# Altium schematic sheet EIS_Inverting_Adapter.SchDoc(EIS_Inverting_Adapter)
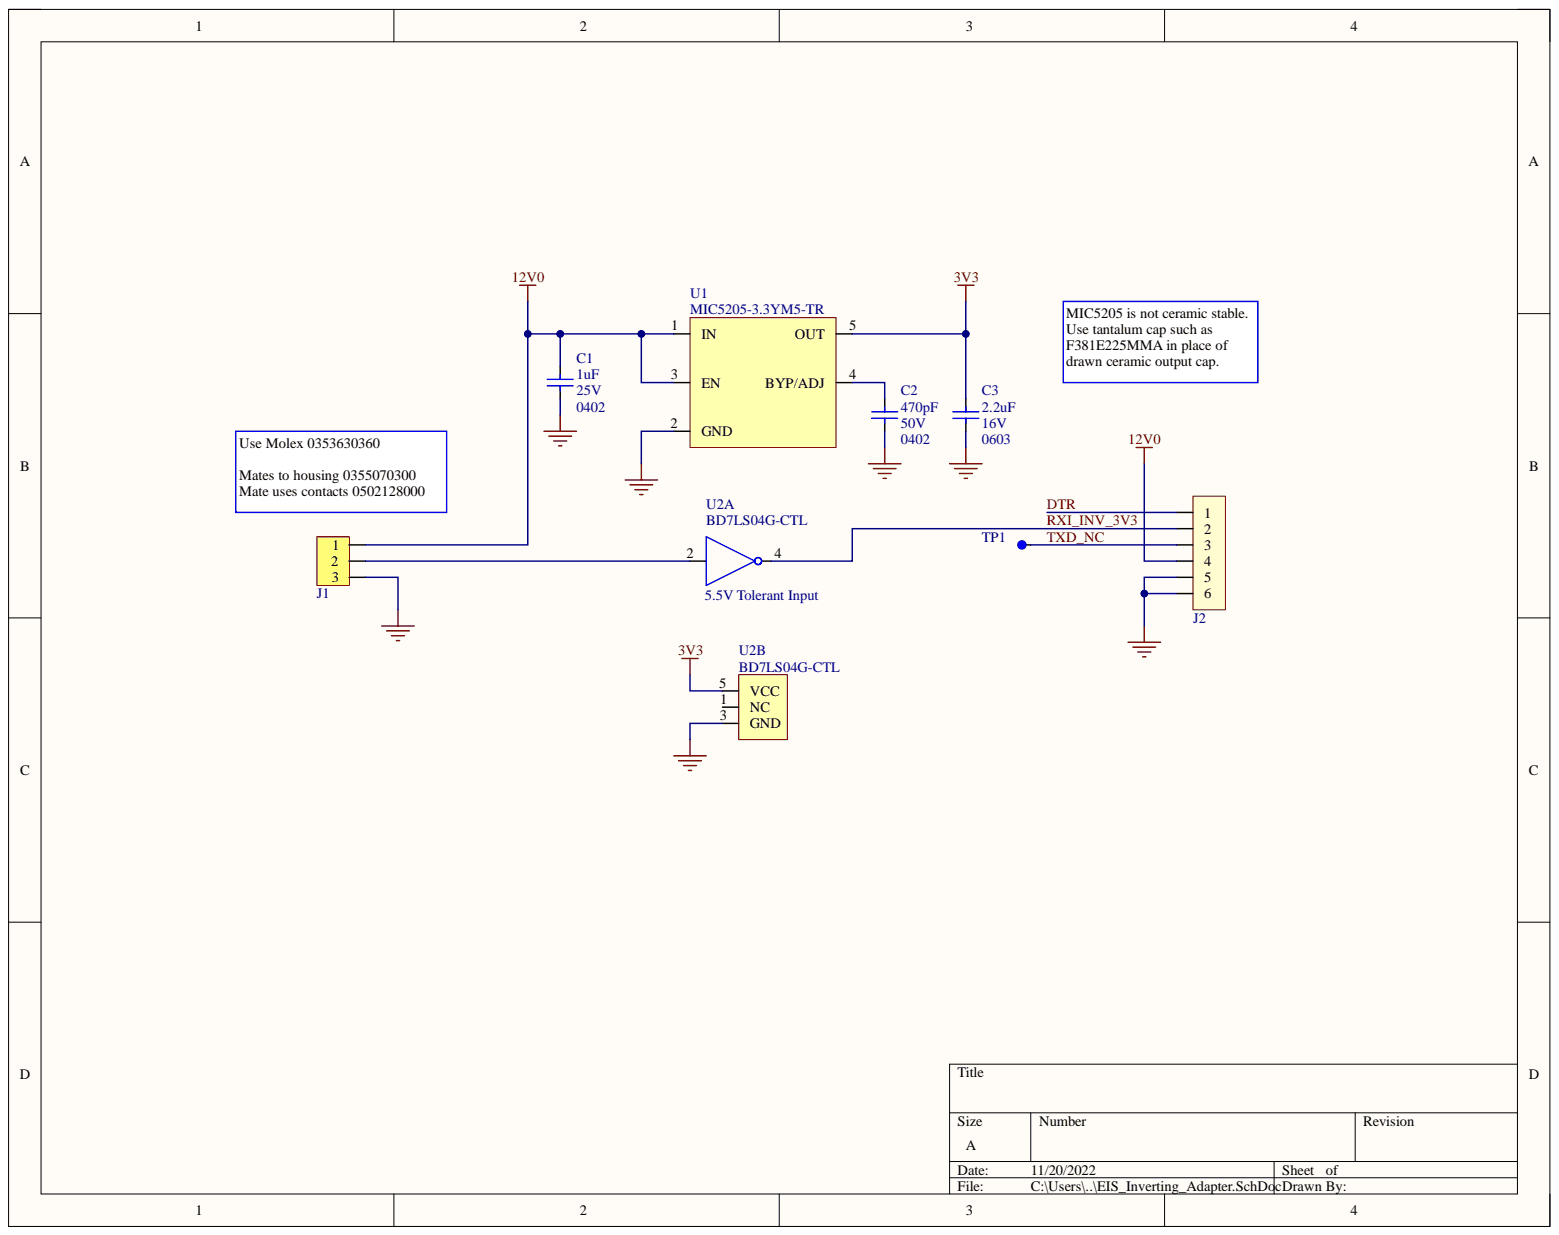
[diagram p0: EIS_Inverting_Adapter.SchDoc]

## Parts
C1  Capacitance: 1uF  Imperial Size: 0402  Voltage: 25V  [CAPC1005X55N]  (hidden comment: E-GCA-0060)
C2  Capacitance: 470pF  Imperial Size: 0402  Voltage: 50V  [CAPC1005X55N]  (hidden comment: E-CAP-0253)
C3  Capacitance: 2.2uF  Imperial Size: 0603  Voltage: 16V  [CAPC1608X90L]  (hidden comment: E-CAP-0056)
J1  E-CON-0138  [SKT2P54_2P41X8X8P51_03]  (value not drawn)
J2  E-CON-0064  [SIP508W63P254L1524H1041Q6]  (value not drawn)
TP1  MFG-0037  [TP_1.2mm_PAD_NOSILK]  (value not drawn)  (no BOM)
U1  MIC5205  Manufacturer Part Number 1: MIC5205-3.3YM5-TR  [SOT95P280X145-5N]
U2  5.5V Tolerant Input  Manufacturer Part Number 1: BD7LS04G-CTL  [SOT95P280X125-5N]  (parts A,B)

## Nets
3V3: C3.1 U1.5(OUT) U2.5(VCC)
12V0: C1.1 J1.1 J2.4 U1.1(IN) U1.3(EN)
GND: C1.2 C2.2 C3.2 J1.3 J2.5 J2.6 U1.2(GND) U2.3(GND)
DTR: J2.1
RXI_INV_3V3: J2.2 U2.4
TXD_NC: J2.3 TP1.1
NetC2_1: C2.1 U1.4(BYP/ADJ)
NetJ1_2: J1.2 U2.2

Not connected: U2 1(NC)

## Library data of the parts
C1 = CMP-002-0003-28: CAP GENERIC, CER, 1uF, 25V, 10%, X5R, 0402i/1005m; Manufacturer: SQUARE AVL; PLM Lifecycle: Design; TQM Critical CI: Unassigned; Component Kind: Standard; Dielectric Material: X5R; Height (Max): 0.55mm; Library Name: Square Altium Server; Manufacturer Part Number: GENERIC; Package/Case: 1005M/0402I; Square Part Number: E-GCA-0060; Tolerance: ±10%
C2 = CMP-002-0132-29: CAP, CER, 470pF, 50V, 5%, X7R, 0402 (1005m); Manufacturer: Murata Electronics North America; Manufacturer Part Number: GRM155R71H471JA01D; ComponentLinkDescription: Datasheet; PLM Lifecycle: In Production; TQM Critical CI: Unassigned; Component Kind: Standard; ComponentLink1Description: Datasheet; ComponentLink1URL: https://www.murata.com/~/media/webrenewal/support/library/catalog/products/capacitor/mlcc/c02e.ashx?la=en-us; ComponentLinkURL: https://www.murata.com/~/media/webrenewal/support/library/catalog/products/capacitor/mlcc/c02e.ashx?la=en-us; Dielectric Material: X7R; Height (Max): 0.55mm; Operating Temperature: -55°C ~ 125°C; Package/Case: 1005m/0402i; Square Part Number: E-CAP-0253; Supplier: Digi-Key; Supplier Part Number: 490-6362-2-ND; Tolerance: ±5%; Library Name: Square Altium Server
C3 = CMP-002-0258-23: CAP CER 2.2UF 16V 10% X5R 0603 (1608m); Manufacturer: Murata Electronics North America; Manufacturer Part Number: GRM188R61C225KE15D; ComponentLinkDescription: Datasheet; PLM Lifecycle: Design; TQM Critical CI: Unassigned; Component Kind: Standard; ComponentLink1Description: Datasheet; ComponentLink1URL: https://www.murata.com/~/media/webrenewal/support/library/catalog/products/capacitor/mlcc/c02e.ashx?la=en-us; ComponentLinkURL: https://www.murata.com/~/media/webrenewal/support/library/catalog/products/capacitor/mlcc/c02e.ashx?la=en-us; Dielectric Material: X5R; Height (Max): 0.90mm; Operating Temperature: -55°C ~ 85°C; Package/Case: 1608m/0603i; Square Part Number: E-CAP-0056; Supplier: Digi-Key; Supplier Part Number: 490-3296-1-ND; Tolerance: 10%; Library Name: Square Altium Server
J1 = CMP-004-0057-08: CONN RCPT .100" 3POS SNGL GOLD; Manufacturer: Samtec Inc; Manufacturer Part Number: SSW-103-01-S-S; ComponentLinkDescription: Datasheet; Current - Rated: 5.7 A; Number of Positions: 3; Pitch (Interface): 0.100" (2.54 mm); TQM Critical CI: Unassigned; Category: Header; Component Kind: Standard; ComponentLink1Description: Datasheet; ComponentLink1URL: http://suddendocs.samtec.com/prints/ssw-1xx-xx-xxx-x-xx-xxx-xx-mkt.pdf; ComponentLinkURL: http://suddendocs.samtec.com/prints/ssw-1xx-xx-xxx-x-xx-xxx-xx-mkt.pdf; Height (Max): 0.335" (8.51 mm); Mounting Type: Through Hole; Number of Rows: 1; Operating Temperature: -55°C ~ 125°C; Orientation: Vertical; Square Part Number: E-CON-0138; Supplier: Digi-Key; Supplier Part Number: SAM1211-03-ND; Type: Receptacle; Library Name: Square Altium Server
J2 = CMP-004-0189-03: CONN HEADER VERT 6POS .100 TIN; Manufacturer: TE Connectivity AMP Connectors; Manufacturer Part Number: 640452-6; ComponentLinkDescription: Datasheet; Number of Positions: 6; Pitch (Interface): 2.54mm; TQM Critical CI: Unassigned; Category: header, male; Component Kind: Standard; ComponentLinkURL: https://www.te.com/commerce/DocumentDelivery/DDEController?Action=srchrtrv&DocNm=640452&DocType=Customer+Drawing&DocLang=English; Square Part Number: E-CON-0064; Supplier: Digikey; Supplier Part Number: A1913-ND; Library Name: Square Altium Server
TP1 = CMP-027-0041-01: Test Point - SM, 1.2mm; Hole Diameter: 0; TQM Critical CI: Unassigned; ClassName: SM_TP; Pad Size: 1.2mm; Square Part Number: MFG-0037; Library Name: Square Altium Server
U1 = ICS-LRG-0001: Linear Voltage Regulator IC Positive Fixed 1 Output 150mA SOT-23-5; Manufacturer 1: Microchip Technology; Control Features: Enable; Current - Quiescent (Iq): 150 µA; Current - Supply (Max): 2.5 mA; Database Table Name: Linear Regulators$; Number of Regulators: 1; Protection Features: Over Current, Over Temperature, Reverse Polarity; PSRR: 75dB (100Hz); Voltage - Input (Max): 16V; Voltage - Output (Min/Fixed): 3.3V; Voltage Dropout (Max): 0.35V @ 150mA; Base Product Number: MIC5205; Component Kind: Standard; Current - Output: 150mA; Library Name: ics.DbLib; Mounting Type: Surface Mount; Operating Temperature: `	 -40°C ~ 125°C; Output Configuration: Positive; Output Type1: Fixed; Package / Case: SC74A, SOT-753; Supplier 1: Digi-Key; Supplier Device Package: SOT-23-5; Supplier Link 1: https://www.digikey.com/en/products/detail/microchip-technology/MIC5205-3-3YM5-TR/771728; Supplier Part Number 1: 576-1259-1-ND; TPN: ICS-LRG-0001; Voltage - Output (Max): -
U2 = ICS-GAT-0001: Automotive Single Inverter; Manufacturer 1: Rohm Semiconductor; Current - Output High, Low: 32mA, 32mA; Current - Quiescent (Max): 10 µA; Database Table Name: Gates and Inverters$; Max Propagation Delay @ V, Max CL: 10ns @ 5V, 50pF; Voltage - Supply: 1.65V ~ 5.5V; Component Kind: Standard; Height - Seated (Max): 1.25mm; Input Logic Level - High: -; Input Logic Level - Low: -; Library Name: ics.DbLib; Logic Type: Inverter; Mounting Type: Surface Mount; Operating Temperature: `-40°C ~ 125°C; Package/Case: SC-74A, SOT-753; Supplier 1: Digi-Key; Supplier Device Package: 5-SSOP; Supplier Link 1: https://www.digikey.com/en/products/detail/rohm-semiconductor/BD7LS04G-CTL/16284177; Supplier Part Number 1: 846-BD7LS04G-CTLCT-ND; TPN: ICS-GAT-0001
